# Altium schematic sheet digital.SchDoc
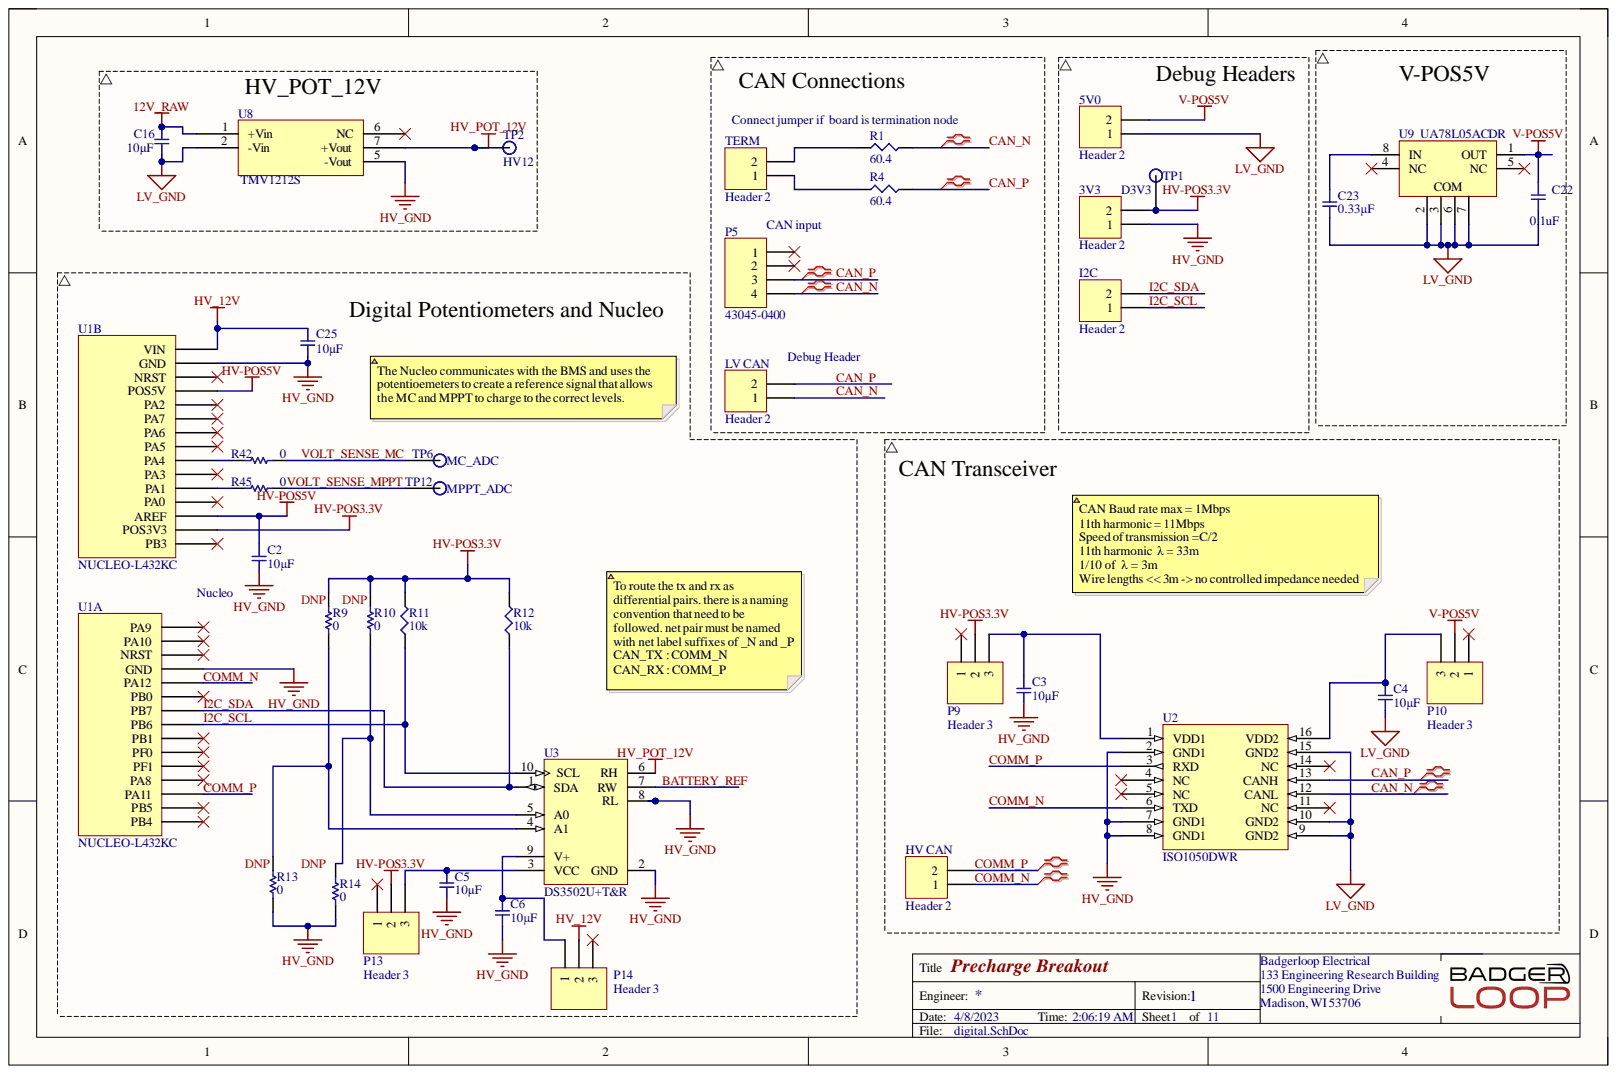
[diagram p0: digital.SchDoc]

## Parts
3V3  Header 2  [Pin Header 1x2 TH Pitch 2.54mm]
5V0  Header 2  [Pin Header 1x2 TH Pitch 2.54mm]
C2  value 10µF  [SMD-0805C]  (hidden comment: GCM21BR71A106KE22L)
C3  value 10µF  [SMD-0805C]  (hidden comment: GCM21BR71A106KE22L)
C4  value 10µF  [SMD-0805C]  (hidden comment: GCM21BR71A106KE22L)
C5  value 10µF  [SMD-0805C]  (hidden comment: GCM21BR71A106KE22L)
C6  value 10µF  [SMD-0805C]  (hidden comment: GCM21BR71A106KE22L)
C16  value 10µF  [SMD-0805C]  (hidden comment: GCM21BR71A106KE22L)
C22  0.1uF  [SMD-0805C]
C23  0.33µF  [SMD-0805C]
C25  value 10µF  [SMD-0805C]  (hidden comment: GCM21BR71A106KE22L)
HV_CAN  Header 2  [Pin Header 1x2 TH Pitch 2.54mm]
I2C  Header 2  [Pin Header 1x2 TH Pitch 2.54mm]
LV_CAN  Header 2  [Pin Header 1x2 TH Pitch 2.54mm]
P5  43045-0400  [430450400]
P9  Header 3  [Pin Header 1x3 TH Pitch 2.54mm]
P10  Header 3  [Pin Header 1x3 TH Pitch 2.54mm]
P13  Header 3  [Pin Header 1x3 TH Pitch 2.54mm]
P14  Header 3  [Pin Header 1x3 TH Pitch 2.54mm]
R1  value 60.4  [SMD-0805-RES]  (hidden comment: ERJ-6ENF60R4V)
R4  value 60.4  [SMD-0805-RES]  (hidden comment: ERJ-6ENF60R4V)
R9  value 0  [RESC2013X60X35ML10T20]  (hidden comment: Resistor 0R +/- 5% 0805 125 mW)
R10  value 0  [RESC2013X60X35ML10T20]  (hidden comment: Resistor 0R +/- 5% 0805 125 mW)
R11  value 10k  [SMD-1206-RES]  (hidden comment: ERJ-P08J103V)
R12  value 10k  [SMD-1206-RES]  (hidden comment: ERJ-P08J103V)
R13  value 0  [RESC2013X60X35ML10T20]  (hidden comment: Resistor 0R +/- 5% 0805 125 mW)
R14  value 0  [RESC2013X60X35ML10T20]  (hidden comment: Resistor 0R +/- 5% 0805 125 mW)
R42  value 0  [RESC2013X60X35ML10T20]  (hidden comment: Resistor 0R +/- 5% 0805 125 mW)
R45  value 0  [RESC2013X60X35ML10T20]  (hidden comment: Resistor 0R +/- 5% 0805 125 mW)
TERM  Header 2  [Pin Header 1x2 TH Pitch 2.54mm]
TP1  D3V3  [Test Point]
TP2  HV12  [Test Point]
TP6  MC_ADC  [Test Point]
TP12  MPPT_ADC  [Test Point]
U1  NUCLEO-L432KC  [NUCLEO-L432KC]  (parts A,B)
U2  ISO1050DWR  [IL 41050TE]
U3  DS3502U+T&R  [MAXM-U10+2_L]
U8  TMV1212S  [TMV1212S-Footprint-1]
U9  UA78L05ACDR  [D0008A_L]

## Nets
12V_RAW: C16.1 U8.1(+Vin)
HV-POS3.3V: 3V3.2 P9.2 P13.2 R9.2 R10.2 R11.2 R12.2 TP1.1 U1.4.14(POS3V3)
HV-POS5V: C2.1 U1.4.4(POS5V) U1.4.13(AREF)
HV_12V: C25.1 P14.2 U1.4.1(VIN)
HV_GND: 3V3.1 C2.2 C3.2 C5.2 C6.2 C25.2 R13.1 R14.1 U1.3.4(GND) U1.4.2(GND) U2.2(GND1) U2.7(GND1) U2.8(GND1) U3.2(GND) U3.8(RL) U8.5(-Vout)
HV_POT_12V: TP2.1 U3.6(RH) U8.7(+Vout)
LV_GND: 5V0.1 C4.2 C16.2 C22.2 C23.2 U2.9(GND2) U2.10(GND2) U2.15(GND2) U8.2(-Vin) U9.2 U9.3 U9.6 U9.7
V-POS5V: 5V0.2 C22.1 P10.2 U9.1(OUT)
BATTERY_REF: U3.7(RW)
CAN_N: LV_CAN.1 P5.4 R1.2 U2.12(CANL)
CAN_P: LV_CAN.2 P5.3 R4.2 U2.13(CANH)
COMM_N: HV_CAN.1 U1.3.5(PA12) U2.6(TXD)
COMM_P: HV_CAN.2 U1.3.13(PA11) U2.3(RXD)
I2C_SCL: I2C.1 R11.1 U1.3.8(PB6) U3.10(SCL)
I2C_SDA: I2C.2 R12.1 U1.3.7(PB7) U3.1(SDA)
VOLT_SENSE_MC: R42.1 TP6.1
VOLT_SENSE_MPPT: R45.1 TP12.1
NetC3_1: C3.1 P9.3 U2.1(VDD1)
NetC4_1: C4.1 P10.3 U2.16(VDD2)
NetC5_1: C5.1 P13.3 U3.3(VCC)
NetC6_1: C6.1 P14.1 U3.9(V+)
NetC23_1: C23.1 U9.8(IN)
NetR1_1: R1.1 TERM.2
NetR4_1: R4.1 TERM.1
NetR9_1: R9.1 R13.2 U3.4(A1)
NetR10_1: R10.1 R14.2 U3.5(A0)
NetR42_2: R42.2 U1.4.9(PA4)
NetR45_2: R45.2 U1.4.11(PA1)
NetU1_3.1: U1.3.1(PA9) U1.3.10(PF0)

Not connected: P5 1 2; P9 1; P10 1; P13 1; P14 3; U1 3.2(PA10) 3.3(NRST) 3.6(PB0) 3.9(PB1) 3.11(PF1) 3.12(PA8) 3.14(PB5) 3.15(PB4) 4.3(NRST) 4.5(PA2) 4.6(PA7) 4.7(PA6) 4.8(PA5) 4.10(PA3) 4.12(PA0) 4.15(PB3); U2 4(NC) 5(NC) 11(NC) 14(NC); U8 6(NC); U9 4(NC) 5(NC)

## Library data of the parts
3V3 5V0 HV_CAN I2C LV_CAN TERM = CMP-002-00072-1: Header, 2-Pin; Component Kind: Standard; Design Item ID: Header 2; LatestRevisionDate: 17-Jul-2002; LatestRevisionNote: Re-released for DXP Platform.; Library Name: Altium Concord Pro on A365; Source: connector.SchLib; Type: Connector; Publisher: Altium Limited
C2-C6 C16 C25 = CMP-001-00205-1: CAP CER 10UF 10V X7R 0805; Manufacturer: Murata Electronics North America; @ qty: 0; Minimum Quantity: 1; Tolerance: 10%; Unit Price (USD): 0.49; Applications: Automotive; Component Kind: Standard; Datasheets: http://www.murata.com/~/media/webrenewal/support/library/catalog/products/capacitor/mlcc/c03e.ashx; Design Item ID: GCM21BR71A106KE22L; Digi-Key Part Number: 490-10674-1-ND; Factory Stock: 0; Features: -; Height - Seated (Max): -; Image: //media.digikey.com/photos/Murata%20Photos/NCP,GCM%20SERIES%200805.jpg; Lead Spacing: -; Lead Style: -; Library Name: Altium Concord Pro on A365; Mounting Type: Surface Mount, MLCC; Operating Temperature: -55°C ~ 125°C; Package / Case: 0805 (2012 Metric); Packaging: Cut Tape (CT); Part Number: GCM21BR71A106KE22L; Part Status: Active; Quantity Available: 125344; Ratings: AEC-Q200; Series: GCM; Source: capacitors.SchLib; Temperature Coefficient: X7R; Voltage: 10V
C22 = CGA4J3X7T2E104K125AA: Cap Ceramic 0.1uF 250V X7T 10% SMD 0805 125°C Blister Plastic T/R; Manufacturer: TDK; Capacitance: 100nF; Case Code (Imperial): 0805; Case Code (Metric): 2012; Case/Package: 0805; Category: Ceramic Capacitors; Component Kind: Standard; ComponentLink1Description: https://datasheet.ciiva.com/22703/1807242-22703721.pdf?src-supplier=Element14; ComponentLink1URL: https://datasheet.ciiva.com/22703/1807242-22703721.pdf?src-supplier=Element14; Construction: Flat; Depth: 1.25mm; Dielectric: X7T; Height: 1.25mm; Length: 2mm; Library Name: capacitors.SchLib; Manufacturer Part Number: CGA4J3X7T2E104K125AA; Max Operating Temperature: 125°C; Min Operating Temperature: -55°C; Mount: Surface Mount; Package Quantity: 2000; Packaging: Tape and Reel; Pricing 1: 1=0.33000, 10=0.22600, 50=0.15820, 100=0.13560, 500=0.10172, 1000=0.08590 (USD); Radiation Hardening: No; Ratings: AEC-Q200; REACH SVHC: No SVHC; Resistor Type: Ceramic; RoHS: Yes; RoHS Compliant: Yes; Series: CGA; Stock 1: 335314; Supplier 1: Digi-Key; Supplier Part Number 1: 0.1uF; Termination: SMD/SMT; Thickness: 0.057inch; Tolerance: 10%; Value: 0.1uF; Voltage Rating: 250V; Voltage Rating (DC): 250V; Weight: 0.000194oz; Width: 1.25mm
C23 = GRM21AR72A334KAC5L: CAP CER 0.33UF 100V X7R 0805; Manufacturer: Murata Electronics North America; @ qty: 0; Minimum Quantity: 1; Tolerance: ±10%; Unit Price (USD): 0.47; Applications: General Purpose; Component Kind: Standard; Datasheets: http://www.murata.com/~/media/webrenewal/support/library/catalog/products/capacitor/mlcc/c02e.pdf; Digi-Key Part Number: 490-6462-1-ND; Factory Stock: 0; Features: -; Height - Seated (Max): -; Image: //media.digikey.com/Renders/Murata%20Renders/0805%20(2012%20Metric)%20.85mm.jpg; Lead Spacing: -; Lead Style: -; Library Name: capacitors.SchLib; Mounting Type: Surface Mount, MLCC; Operating Temperature: -55°C ~ 125°C; Package / Case: 0805 (2012 Metric); Packaging: Cut Tape (CT); Part Number: GRM21AR72A334KAC5L; Part Status: Active; Quantity Available: 80183; Ratings: -; Series: GRM; Size / Dimension: 0.079" L x 0.049" W (2.00mm x 1.25mm); Temperature Coefficient: X7R; Thickness (Max): 0.039" (1.00mm); Value: 0.33µF; Voltage: 100V
P5 = CMP-002-00097-1: Male connector dual row 90° 3 mm Pole no. 2x2 Micro-Fit, 43045-0400, Molex; Connector Type: Male Pin; Contact Resistance: 10mR; Number of Contacts: 4; Pitch: 3mm; Row Spacing: 0.118inch; Voltage Rating: 250V; Color: Black; Component Kind: Standard; ComponentLink1Description: https://datasheet.ciiva.com/712/430450200-sd-712017.pdf?src-supplier=Digi-Key; ComponentLink1URL: https://datasheet.ciiva.com/712/430450200-sd-712017.pdf?src-supplier=Digi-Key; ComponentLink2Description: https://datasheet.ciiva.com/20209/22-3744e-20209522.pdf?src-supplier=Rapid+Electronics; ComponentLink2URL: https://datasheet.ciiva.com/20209/22-3744e-20209522.pdf?src-supplier=Rapid+Electronics; ComponentLink3Description: https://datasheet.ciiva.com/11746/0900766b80027348-11746988.pdf?src-supplier=RSComponents; ComponentLink3URL: https://datasheet.ciiva.com/11746/0900766b80027348-11746988.pdf?src-supplier=RSComponents; ComponentLink4Description: https://datasheet.ciiva.com/30193/145420430450400-pcb-headers-30193310.pdf?src-supplier=Onlinecomponents.com; ComponentLink4URL: https://datasheet.ciiva.com/30193/145420430450400-pcb-headers-30193310.pdf?src-supplier=Onlinecomponents.com; Contact Material: Brass; Contact Plating: Tin; Depth: 12.2mm; Design Item ID: 43045-0400; Fastening Type: Ramp; Flammability Rating: UL94 V-0; Housing Color: Black; Housing Material: Polymer; Length: 10.15mm; Library Name: Altium Concord Pro on A365; Manufacturer: Molex; Manufacturer Part Number: 43045-0400; Max Operating Temperature: 105°C; Min Operating Temperature: -40°C; Mount: Through Hole; Number of Positions: 4; Number of Rows: 2; Orientation: Right Angle; Packaging: Tray; Pricing 1: 1=1.33000, 10=1.16700, 100=1.00670, 500=0.82368, 1000=0.73216, 5000=0.64064, 10000=0.60861 (USD); RoHS: Yes; Source: connector.SchLib; Stock 1: 5904; Termination: Solder
P9 P10 P13 P14 = CMP-002-00073-1: Header, 3-Pin; Component Kind: Standard; Design Item ID: Header 3; LatestRevisionDate: 17-Jul-2002; LatestRevisionNote: Re-released for DXP Platform.; Library Name: Altium Concord Pro on A365; Source: connector.SchLib; Type: Connector; Publisher: Altium Limited
R1 R4 = CMP-009-01480-1: RES SMD 60.4 OHM 1% 1/8W 0805; Manufacturer: Panasonic Electronic Components; @ qty: 1500; Factory Stock: 0; Minimum Quantity: 1; Power (Watts): 0.125W, 1/8W; Temperature Coefficient: ±100ppm/°C; Unit Price (USD): 0.00729; Component Kind: Standard; Composition: Thick Film; Datasheets: http://industrial.panasonic.com/www-cgi/jvcr13pz.cgi?E+PZ+3+AOA0002+ERJ6ENF60R4V+7+WW; Design Item ID: ERJ-6ENF60R4V; Digi-Key Part Number: P60.4CCT-ND; Failure Rate: -; Features: Automotive AEC-Q200; Height - Seated (Max): 0.028" (0.70mm); Image: //media.digikey.com/Renders/Panasonic%20Renders/ERJ-0805%20Pkg.jpg; Library Name: Altium Concord Pro on A365; Number of Terminations: 2; Operating Temperature: -55°C ~ 155°C; Package / Case: 0805 (2012 Metric); Packaging: Cut Tape (CT); Part Number: ERJ-6ENF60R4V; Part Status: Active; Quantity Available: 57422; Series: ERJ; Size / Dimension: 0.079" L x 0.049" W (2.00mm x 1.25mm); Source: resistors.SchLib; Supplier Device Package: 0805; Tolerance: 1%
R9 R10 R13 R14 R42 R45 = CMP-009-00007-5: Chip Resistor, 0 Ohm, 0.125 W, -55 to 155 degC, 0805 (2012 Metric); Power: 125mW; Voltage Rating: 50V; Case/Package: 0805; Component Kind: Standard; Library Name: Altium Concord Pro on A365; Max Operating Temperature: 155°C; Min Operating Temperature: -55°C; Mounting Technology: SM; Pins: 2; RoHS Compliant: True; Tolerance: 5%
R11 R12 = CMP-009-00681-1: RES SMD 10K OHM 5% 2/3W 1206; Manufacturer: Panasonic Electronic Components; @ qty: 1500; Factory Stock: 0; Minimum Quantity: 1; Power (Watts): 0.667W, 2/3W; Temperature Coefficient: ±200ppm/°C; Unit Price (USD): 0.02178; Component Kind: Standard; Composition: Thick Film; Datasheets: https://industrial.panasonic.com/cdbs/www-data/pdf/RDA0000/AOA0000C243.pdf; Design Item ID: ERJ-P08J103V; Digi-Key Part Number: P10KALCT-ND; Failure Rate: -; Features: Automotive AEC-Q200, Pulse Withstanding; Image: //media.digikey.com/Renders/Panasonic%20Renders/ERJ-1206%20Pkg.jpg; Library Name: Altium Concord Pro on A365; Number of Terminations: 2; Operating Temperature: -55°C ~ 155°C; Package / Case: 1206 (3216 Metric); Packaging: Cut Tape (CT); Part Number: ERJ-P08J103V; Part Status: Active; Quantity Available: 46069; Series: ERJ; Source: resistors.SchLib; Supplier Device Package: 1206; Tolerance: 5%
TP1 TP2 TP6 TP12 = CMP-039-000000-1: SMD TEST POINT; Component Kind: Standard; Design Item ID: TP; Library Name: Altium Concord Pro on A365; Source: misc.SchLib
U1 = CMP-020-000017-1: BOARD NUCLEO STM32F303K8T6; Manufacturer: STMicroelectronics; Core Architecture: ARM; Evaluation Kit: Yes; Number of Bits: 32; Component Kind: Standard; ComponentLink1Description: http://www.farnell.com/datasheets/2064195.pdf?src-supplier=Farnell; ComponentLink1URL: http://www.farnell.com/datasheets/2064195.pdf?src-supplier=Farnell; ComponentLink2Description: http://www.st.com/content/ccc/resource/technical/document/data_brief/b1/d8/13/d4/b0/b7/4b/6e/DM00214578.pdf/files/DM00214578.pdf/jcr:content/translations/en.DM00214578.pdf?src-supplier=Digi-Key; ComponentLink2URL: http://www.st.com/content/ccc/resource/technical/document/data_brief/b1/d8/13/d4/b0/b7/4b/6e/DM00214578.pdf/files/DM00214578.pdf/jcr:content/translations/en.DM00214578.pdf?src-supplier=Digi-Key; ComponentLink3Description: http://www.farnell.com/datasheets/1966773.pdf?src-supplier=Element14; ComponentLink3URL: http://www.farnell.com/datasheets/1966773.pdf?src-supplier=Element14; ComponentLink4Description: http://www.farnell.com/datasheets/2064195.pdf?src-supplier=Newark; ComponentLink4URL: http://www.farnell.com/datasheets/2064195.pdf?src-supplier=Newark; ComponentLink5Description: http://www.st.com/st-web-ui/static/active/en/resource/technical/document/user_manual/DM00116575.pdf?s_searchtype=keyword&src-supplier=Avnet+Asia; ComponentLink5URL: http://www.st.com/st-web-ui/static/active/en/resource/technical/document/user_manual/DM00116575.pdf?s_searchtype=keyword&src-supplier=Avnet+Asia; ComponentLink6Description: http://www.st.com/st-web-ui/static/active/en/resource/technical/document/user_manual/DM00116575.pdf?s_searchtype=keyword&src-supplier=Avnet; ComponentLink6URL: http://www.st.com/st-web-ui/static/active/en/resource/technical/document/user_manual/DM00116575.pdf?s_searchtype=keyword&src-supplier=Avnet; ComponentLink7Description: http://www.farnell.com/datasheets/2064195.pdf?src-supplier=Element14; ComponentLink7URL: http://www.farnell.com/datasheets/2064195.pdf?src-supplier=Element14; Design Item ID: NUCLEO-L432KC; Lead Free: Lead Free; Library Name: Altium Concord Pro on A365; Manufacturer Part Number: NUCLEO-L432KC; PartId: 1; Pricing 1: 1=10.99000 (USD); Pricing 2: 1=12.40000 (USD); REACH SVHC: No SVHC; RoHS: Yes; Source: ic.SchLib; Stock 1: 171; Stock 2: 56
U2 = CMP-031-00010-1: IC TXRX/ISO HALF 1/1 16SOIC; Manufacturer: Texas Instruments; Number of Functions: 1; Package Body Material: Plastic; Qualification Status: Not Qualified; Supply Voltage-Nom: 5V; Telecom IC Type: INTERFACE CIRCUIT; Category: Interface ICs; Component Kind: Standard; ComponentLink1Description: https://www.ti.com:443/lit/ds/symlink/iso1050.pdf?src-supplier=IHS+Markit; ComponentLink1URL: https://www.ti.com:443/lit/ds/symlink/iso1050.pdf?src-supplier=IHS+Markit; ComponentLink2Description: https://datasheet.ciiva.com/4782/slls983e-121851-4782209.pdf?src-supplier=Mouser; ComponentLink2URL: https://datasheet.ciiva.com/4782/slls983e-121851-4782209.pdf?src-supplier=Mouser; ComponentLink3Description: https://datasheet.ciiva.com/26913/getdatasheetpartid-573378-26913111.pdf?src-supplier=Verical; ComponentLink3URL: https://datasheet.ciiva.com/26913/getdatasheetpartid-573378-26913111.pdf?src-supplier=Verical; Design Item ID: ISO1050DWR; Height - Seated (Max): 2.65mm; JESD-30 Code: R-PDSO-G16; JESD-609 Code: e4; Length: 10.3mm; Library Name: Altium Concord Pro on A365; Manufacturer Part Number: ISO1050DWR; Max Operating Temperature: 105°C; Min Operating Temperature: -55°C; Moisture Sensitivity Level: 2; Package Code: SOP; Package Shape: Rectangular; Package Style: SMALL OUTLINEMeter; Peak Reflow Temperature (Cel): 260°C; Pins: 16; Pricing 1: 1=6.50000, 10=5.84000, 25=5.52000, 50=5.52000, 100=4.79000, 1000=3.56000, 10000=3.56000 (USD); REACH SVHC: Yes; RoHS: Yes; RoHS Compliant: Yes; Source: ic.SchLib; Surface Mount: Yes; Temperature Grade: OTHER; Terminal Finish: Nickel; Terminal Form: Gull Wing; Terminal Pitch: 1.27mm; Terminal Position: DUAL; Width: 7.5mm
U3 = CMP-008-000013-1: Digital Potentiometer, 1 Func, 10000ohm, 2-wire Serial Control Interface, 128 Positions, PDSO10; Component Kind: Standard; Library Name: Altium Concord Pro on A365
U8 = CMP-008-000009-3: DC-DC Regulated Power Supply Module, 1 Output, 1W, Hybrid; Component Kind: Standard; Library Name: Altium Concord Pro on A365
U9 = CMP-031-00025-1: Fixed Positive Standard Regulator, 5VBIPolar, PDSO8; Component Kind: Standard; Library Name: Altium Concord Pro on A365; RoHS Compliant: Yes
